# Altium schematic sheet [11] - USB 3.0 DRP I.SchDoc("[11] - USB 3.0 DRP I")
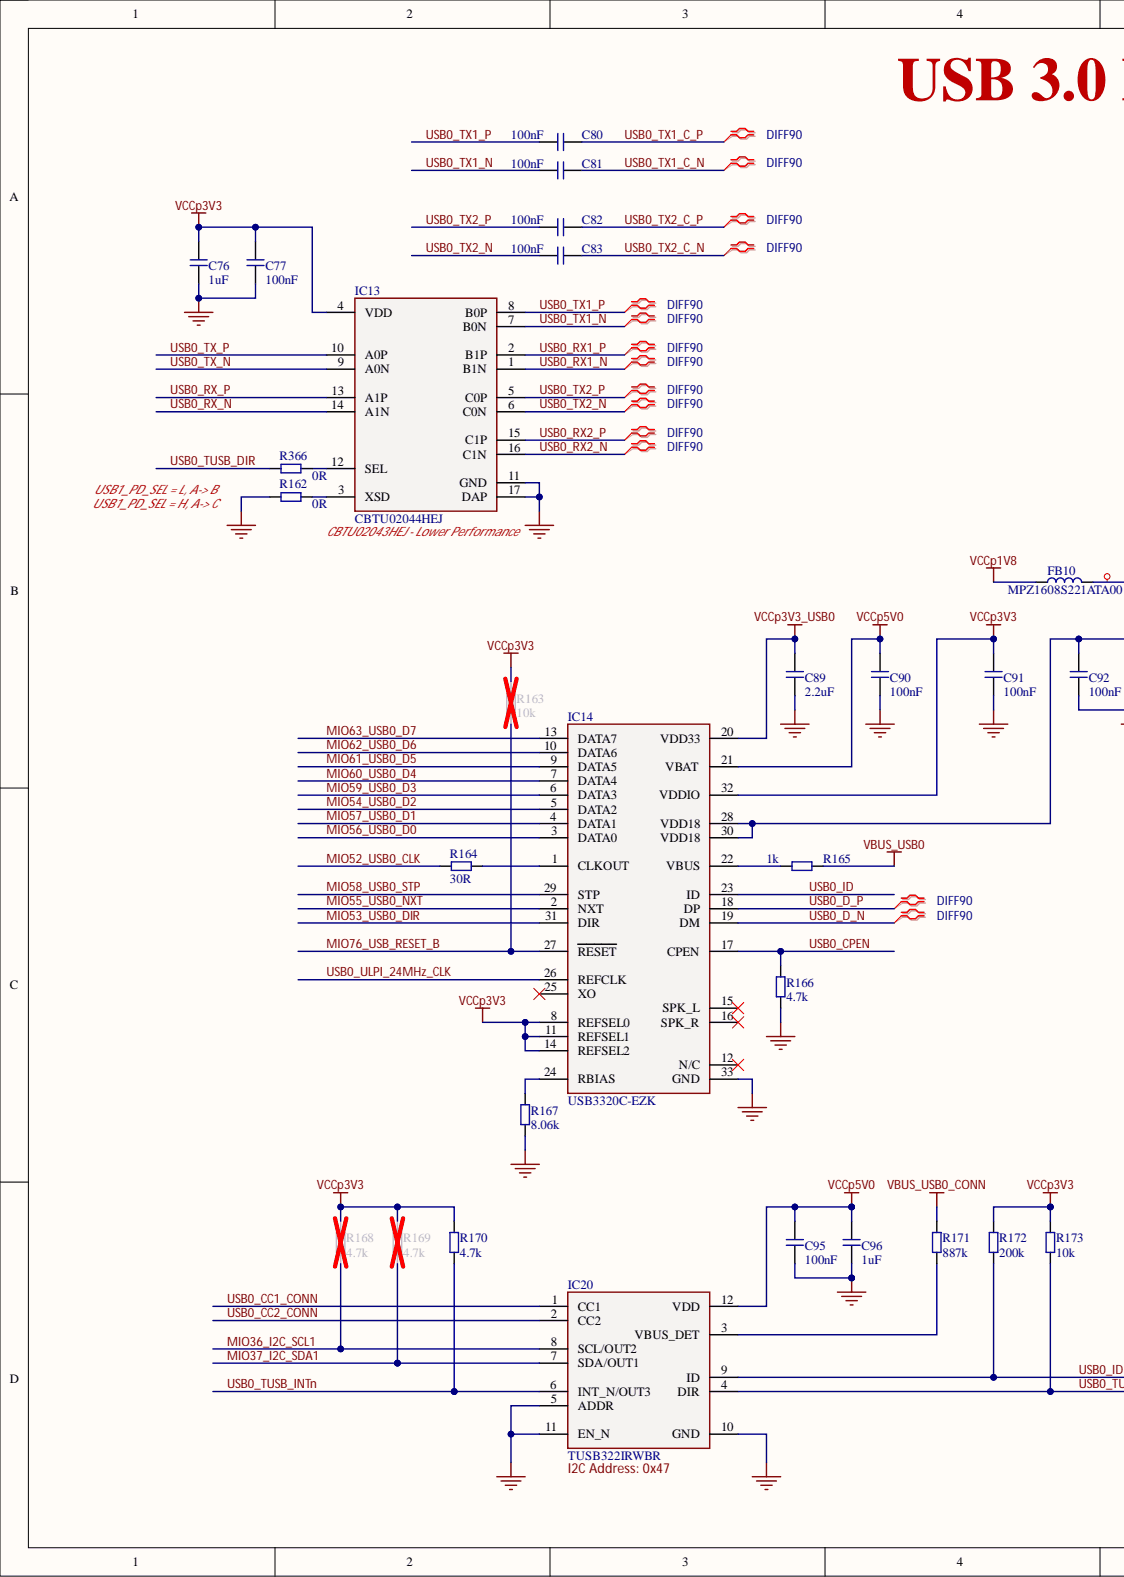
[diagram p0: [11] - USB 3.0 DRP I.SchDoc, left]
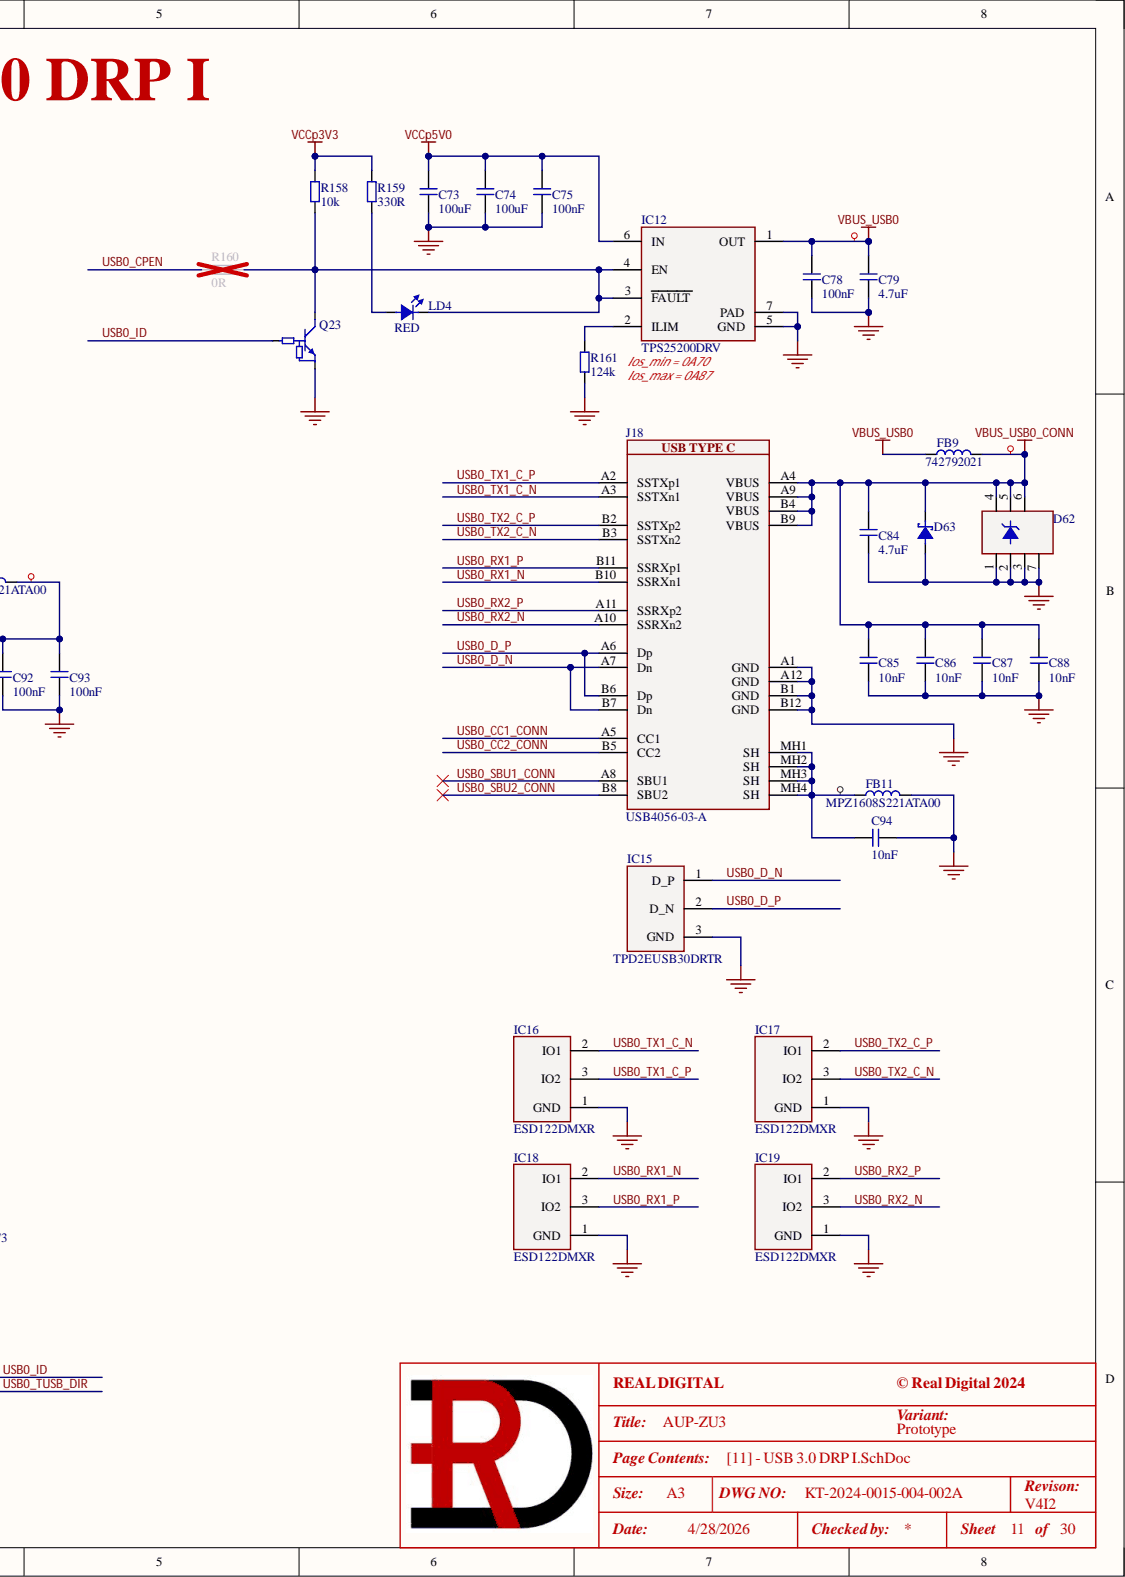
[diagram p1: [11] - USB 3.0 DRP I.SchDoc, right]

## Parts
C73  100uF  [C1210]
C74  100uF  [C1210]
C75  100nF  [C0402]
C76  1uF  [C0603]
C77  100nF  [C0201]
C78  100nF  [C0402]
C79  4.7uF  [C0603]
C80  100nF  [C0201]
C81  100nF  [C0201]
C82  100nF  [C0201]
C83  100nF  [C0201]
C84  4.7uF  [C0603]
C85  10nF  [C0402]
C86  10nF  [C0402]
C87  10nF  [C0402]
C88  10nF  [C0402]
C89  2.2uF  [C0603]
C90  100nF  [C0201]
C91  100nF  [C0201]
C92  100nF  [C0201]
C93  100nF  [C0201]
C94  10nF  [C0402]
C95  100nF  [C0201]
C96  1uF  [C0402]
D62  TVS0500DRVR  [DRV0006A_WSON_6 - TI]  (value not drawn)
D63  NSR20F30NXT5G  [DSN2 - ONSEMI]  (value not drawn)
FB9  742792021  [BLM21]
FB10  MPZ1608S221ATA00  [MPZ1608]
FB11  MPZ1608S221ATA00  [MPZ1608]
IC12  TPS25200DRV  [DRV0006A_WSON_6 - TI]
IC13  CBTU02044HEJ  [SOT1832-1_HUQFN16 - NXP]
IC14  USB3320C-EZK  [QFN32_5x5mm - Microchip]
IC15  TPD2EUSB30DRTR  [DRT0003A - TI]
IC16  ESD122DMXR  [DMX0003A - TI]
IC17  ESD122DMXR  [DMX0003A - TI]
IC18  ESD122DMXR  [DMX0003A - TI]
IC19  ESD122DMXR  [DMX0003A - TI]
IC20  TUSB322IRWBR  [RWB0012A - TI]
J18  USB4056-03-A  [USB4056-03-A - GCT]
LD4  RED  [LD0603_RED]
Q23  DTC043ZEBTL  [SC89-EMT3F]  (value not drawn)
R158  10k  [R0402]
R159  330R  [R0402]
R160  0R  [R0402]
R161  124k  [R0402]
R162  0R  [R0402]
R163  10k  [R0402]
R164  30R  [R0201]
R165  1k  [R0402]
R166  4.7k  [R0402]
R167  8.06k  [R0402]
R168  4.7k  [R0402]
R169  4.7k  [R0402]
R170  4.7k  [R0402]
R171  887k  [R0402]
R172  200k  [R0402]
R173  10k  [R0402]
R366  0R  [R0402]

## Nets
GND: C73.1 C74.1 C75.1 C76.1 C77.1 C78.1 C79.1 C84.1 C85.1 C86.1 C87.1 C88.1 C89.1 C90.1 C91.1 C92.1 C93.1 C94.2 C95.1 C96.1 D62.1 D62.2 D62.3 D62.7 D63.A FB11.2 IC12.5(GND) IC12.7(PAD) IC13.11(GND) IC13.17(DAP) IC14.33(GND) IC15.3 IC16.1 IC17.1 IC18.1 IC19.1 IC20.5(ADDR) IC20.10(GND) IC20.11(EN_N) J18.A1(GND) J18.A12(GND) J18.B1(GND) J18.B12(GND) Q23.GND R161.1 R162.2 R166.1 R167.1
VBUS_USB0: C78.2 C79.2 FB9.1 IC12.1(OUT) R165.2
VBUS_USB0_CONN: C84.2 C85.2 C86.2 C87.2 C88.2 D62.4 D62.5 D62.6 D63.K FB9.2 J18.A4(VBUS) J18.A9(VBUS) J18.B4(VBUS) J18.B9(VBUS) R171.2
VCCp1V8: FB10.2
VCCp3V3: C76.2 C77.2 C91.2 IC13.4(VDD) IC14.8(REFSEL0) IC14.11(REFSEL1) IC14.14(REFSEL2) IC14.32(VDDIO) R158.2 R159.2 R163.2 R168.2 R169.2 R170.2 R172.2 R173.2
VCCp3V3_USB0: C89.2 IC14.20(VDD33)
VCCp5V0: C73.2 C74.2 C75.2 C90.2 C95.2 C96.2 IC12.6(IN) IC14.21(VBAT) IC20.12(VDD)
MIO36_I2C_SCL1: IC20.8(SCL/OUT2) R168.1
MIO37_I2C_SDA1: IC20.7(SDA/OUT1) R169.1
MIO52_USB0_CLK: R164.2
MIO53_USB0_DIR: IC14.31(DIR)
MIO54_USB0_D2: IC14.5(DATA2)
MIO55_USB0_NXT: IC14.2(NXT)
MIO56_USB0_D0: IC14.3(DATA0)
MIO57_USB0_D1: IC14.4(DATA1)
MIO58_USB0_STP: IC14.29(STP)
MIO59_USB0_D3: IC14.6(DATA3)
MIO60_USB0_D4: IC14.7(DATA4)
MIO61_USB0_D5: IC14.9(DATA5)
MIO62_USB0_D6: IC14.10(DATA6)
MIO63_USB0_D7: IC14.13(DATA7)
MIO76_USB_RESET_B: IC14.27(RESET) R163.1
USB0_CC1_CONN: IC20.1(CC1) J18.A5(CC1)
USB0_CC2_CONN: IC20.2(CC2) J18.B5(CC2)
USB0_CPEN: IC14.17(CPEN) R160.1 R166.2
USB0_D_N: IC14.19(DM) IC15.1 J18.A7(Dn) J18.B7(Dn)
USB0_D_P: IC14.18(DP) IC15.2 J18.A6(Dp) J18.B6(Dp)
USB0_ID: IC14.23(ID) IC20.9(ID) Q23.IN R172.1
USB0_RX1_N: IC13.1(B1N) IC18.2 J18.B10(SSRXn1)
USB0_RX1_P: IC13.2(B1P) IC18.3 J18.B11(SSRXp1)
USB0_RX2_N: IC13.16(C1N) IC19.3 J18.A10(SSRXn2)
USB0_RX2_P: IC13.15(C1P) IC19.2 J18.A11(SSRXp2)
USB0_RX_N: IC13.14(A1N)
USB0_RX_P: IC13.13(A1P)
USB0_SBU1_CONN: J18.A8(SBU1)
USB0_SBU2_CONN: J18.B8(SBU2)
USB0_TUSB_DIR: IC20.4(DIR) R173.1 R366.2
USB0_TUSB_INTn: IC20.6(INT_N/OUT3) R170.1
USB0_TX1_C_N: C81.2 IC16.2 J18.A3(SSTXn1)
USB0_TX1_C_P: C80.2 IC16.3 J18.A2(SSTXp1)
USB0_TX1_N: C81.1 IC13.7(B0N)
USB0_TX1_P: C80.1 IC13.8(B0P)
USB0_TX2_C_N: C83.2 IC17.3 J18.B3(SSTXn2)
USB0_TX2_C_P: C82.2 IC17.2 J18.B2(SSTXp2)
USB0_TX2_N: C83.1 IC13.6(C0N)
USB0_TX2_P: C82.1 IC13.5(C0P)
USB0_TX_N: IC13.9(A0N)
USB0_TX_P: IC13.10(A0P)
USB0_ULPI_24MHz_CLK: IC14.26(REFCLK)
NetC92_2: C92.2 C93.2 FB10.1 IC14.28(VDD18) IC14.30(VDD18)
NetC94_1: C94.1 FB11.1 J18.MH1(SH) J18.MH2(SH) J18.MH3(SH) J18.MH4(SH)
NetIC12_2: IC12.2(ILIM) R161.2
NetIC12_3: IC12.3(FAULT) IC12.4(EN) LD4.K Q23.OUT R158.1 R160.2
NetIC13_3: IC13.3(XSD) R162.1
NetIC13_12: IC13.12(SEL) R366.1
NetIC14_1: IC14.1(CLKOUT) R164.1
NetIC14_22: IC14.22(VBUS) R165.1
NetIC14_24: IC14.24(RBIAS) R167.2
NetIC20_3: IC20.3(VBUS_DET) R171.1
NetLD4_A: LD4.A R159.1

Not connected: IC14 12(N/C) 15(SPK_L) 16(SPK_R) 25(XO)

## Library data of the parts
C73 C74 = GRM32ER61A107ME20K: CAP CER 100uF 10V X5R 1210; Manufacturer 1: Murata Electronics; .Checked_PCB: RV; C P/N: RD-111-0112; LoadStatus: Load; Tolerance: 20%; Category: Capacitors; Component Kind: Standard; Library Name: KT-RealDigital.IntLib; Manufacturer 1 Part Number: GRM32ER61A107ME20K; Temperature Coefficient: X5R; Voltage - Rated: 10V; .Checked_SCH: RV; .Creator: RV
C75 C78 = GRM155R71E104KE14J: CAP CER 0.1uF 25V X7R 0402; Manufacturer 1: Murata Electronics; .Checked_PCB: AC; .Creator: RV; C P/N: RD-111-0006; LoadStatus: Load; Tolerance: 10%; Category: Capacitors; Component Kind: Standard; Library Name: KT-RealDigital.IntLib; Manufacturer 1 Part Number: GRM155R71E104KE14J; Temperature Coefficient: X7R; Voltage - Rated: 25V; .Checked_SCH: AC
C76 = GRM188R71A105KA61D: CAP CER 1uF 10V X7R 0603; Manufacturer 1: Murata Electronics; .Checked_PCB: AC; .Creator: RV; C P/N: RD-111-0071; LoadStatus: Load; Tolerance: 10%; Category: Capacitors; Component Kind: Standard; Library Name: KT-RealDigital.IntLib; Manufacturer 1 Part Number: GRM188R71A105KA61D; Temperature Coefficient: X7R; Voltage - Rated: 10V; .Checked_SCH: AC
C77 C80-C83 C90-C93 C95 = GRM033C71C104KE14D: CAP CER 0.1uF 16V X7S 0201; Manufacturer 1: Murata Electronics; .Checked_PCB: RV; C P/N: 111-0800; LoadStatus: Load; Tolerance: 10%; Category: Capacitors; Component Kind: Standard; Library Name: KT-RealDigital.IntLib; Manufacturer 1 Part Number: GRM033C71C104KE14D; Temperature Coefficient: X7S; Voltage - Rated: 16V; .Checked_SCH: RV; .Creator: RV
C79 C84 = GRM188R6YA475KE15D: CAP CER 4.7uF 35V X5R 0603; Manufacturer 1: Murata Electronics; .Checked_PCB: DG; .Creator: RV; C P/N: RD-111-0064; LoadStatus: Load; Tolerance: 10%; Category: Capacitors; Component Kind: Standard; Library Name: KT-RealDigital.IntLib; Manufacturer 1 Part Number: GRM188R6YA475KE15D; Temperature Coefficient: X5R; Voltage - Rated: 35V; .Checked_SCH: DG
C85-C88 C94 = GRM155R71H103KA88J: CAP CER 10000pF 50V X7R 0402; Manufacturer 1: Murata Electronics; .Checked_PCB: AC; .Creator: RV; C P/N: RD-111-0003; LoadStatus: Load; Tolerance: 10%; Category: Capacitors; Component Kind: Standard; Library Name: KT-RealDigital.IntLib; Manufacturer 1 Part Number: GRM155R71H103KA88J; Temperature Coefficient: X7R; Voltage - Rated: 50V; .Checked_SCH: AC
C89 = GRM188R71A225KE15J: CAP CER 2.2uF 10V X7R 0603; Manufacturer 1: Murata Electronics; .Checked_PCB: AC; .Creator: RV; C P/N: RD-111-0023; LoadStatus: Load; Tolerance: 10%; Category: Capacitors; Component Kind: Standard; Library Name: KT-RealDigital.IntLib; Manufacturer 1 Part Number: GRM188R71A225KE15J; Temperature Coefficient: X7R; Voltage - Rated: 10V; .Checked_SCH: AC
C96 = GRM155Z71A105KE01J: CAP CER 1uF 10V X7R 0402; Manufacturer 1: Murata Electronics; .Checked_PCB: RV; C P/N: RD-111-0058; LoadStatus: Load; Tolerance: 10%; Category: Capacitors; Component Kind: Standard; Library Name: KT-RealDigital.IntLib; Manufacturer 1 Part Number: GRM155Z71A105KE01J; Temperature Coefficient: X7R; Voltage - Rated: 10V; .Checked_SCH: RV; .Creator: RV
D62 = TVS0500DRVR: 5V flat-clamp surge protection device; Manufacturer 1: Texas Instruments; .Checked_PCB: DG; .Creator: RV; C P/N: RD-121-0528; LoadStatus: Load; Category: TVS - Diodes; Component Kind: Standard; Library Name: KT-RealDigital.IntLib; Manufacturer 1 Part Number: TVS0500DRVR; .Checked_SCH: DG
D63 = NSR20F30NXT5G: DIODE SCHOTTKY 30V 2A 2DSN; Manufacturer 1: onsemi; .Checked_PCB: RV; C P/N: RD-121-0014; LoadStatus: Load; Category: Diodes; Component Kind: Standard; Library Name: KT-RealDigital.IntLib; Manufacturer 1 Part Number: NSR20F30NXT5G; .Checked_SCH: RV; .Creator: RV
FB9 = 742792021: FERRITE BEAD 22 OHM 0805 1LN; Manufacturer 1: Würth Elektronik; .Checked_PCB: DG; .Creator: RV; C P/N: RD-131-0023; LoadStatus: Load; Category: Ferrite Beads; Component Kind: Standard; Library Name: KT-RealDigital.IntLib; Manufacturer 1 Part Number: 742792021; .Checked_SCH: DG
FB10 FB11 = MPZ1608S221ATA00: FERRITE BEAD 220 OHM 0603 1LN; Manufacturer 1: TDK Corporation; .Checked_PCB: AC; .Checked_SCH: RV; C P/N: CY-121-0068; LoadStatus: Load; Category: Ferrite Beads; Component Kind: Standard; Library Name: KT-RealDigital.IntLib; Manufacturer 1 Part Number: MPZ1608S221ATA00; .Creator: RV
IC12 = TPS25200DRV: IC PWR MGR EFUSE 6.5V 2.8A 6SON; Manufacturer 1: Texas Instruments; .Checked_PCB: RV; C P/N: RD-126-0094; LoadStatus: Load; Category: IC; Component Kind: Standard; Library Name: KT-RealDigital.IntLib; Manufacturer 1 Part Number: TPS25200DRV; .Checked_SCH: RV; .Creator: RV
IC13 = CBTU02044HEJ: SWITCH 1-2 PCIE GEN4 HUQFN16; Manufacturer 1: NXP USA Inc.; .Checked_PCB: DG; .Creator: RV; C P/N: RD-126-0100; LoadStatus: Load; Category: IC; Component Kind: Standard; Library Name: KT-RealDigital.IntLib; Manufacturer 1 Part Number: CBTU02044HEJ; .Checked_SCH: DG
IC14 = USB3320C-EZK: IC TRANSCEIVER HALF 1/1 32QFN; Manufacturer 1: Microchip Technology; .Checked_PCB: RV; C P/N: 126-1133; LoadStatus: Load; Category: IC; Component Kind: Standard; Library Name: KT-RealDigital.IntLib; Manufacturer 1 Part Number: USB3320C-EZK; .Checked_SCH: RV; .Creator: RV
IC15 = TPD2EUSB30DRTR: TVS DIODE 5.5V 8V SOT3; Manufacturer 1: Texas Instruments; .Checked_PCB: RV; C P/N: 121-0524; LoadStatus: Load; Category: TVS - Diodes; Component Kind: Standard; Library Name: KT-RealDigital.IntLib; Manufacturer 1 Part Number: TPD2EUSB30DRTR; .Checked_SCH: RV; .Creator: RV
IC16-IC19 = ESD122DMXR: TVS DIODE 3.6VWM 8.4VC 3X2SON; Manufacturer 1: Texas Instruments; .Checked_PCB: DG; .Creator: RV; C P/N: 121-0550; LoadStatus: Load; Category: TVS - Diodes; Component Kind: Standard; Library Name: KT-RealDigital.IntLib; Manufacturer 1 Part Number: ESD122DMXR; .Checked_SCH: DG
IC20 = TUSB322IRWBR: IC INTERFACE SPECIALIZED 12X2QFN; Manufacturer 1: Texas Instruments; .Checked_PCB: RV; C P/N: 126-1503; LoadStatus: Load; Category: IC; Component Kind: Standard; Library Name: KT-RealDigital.IntLib; Manufacturer 1 Part Number: TUSB322IRWBR; .Checked_SCH: RV; .Creator: RV
J18 = USB4056-03-A: USB C 3.2 REC, HORZ, HYBRID PCB; Manufacturer 1: GCT; .Checked_PCB: RV; C P/N: EP-163-0073; LoadStatus: Load; Category: Connectors; Component Kind: Standard; Library Name: KT-RealDigital.IntLib; Manufacturer 1 Part Number: USB4056-03-A; .Checked_SCH: RV; .Creator: RV
LD4 = LED_RED: LED RED 0603; Manufacturer 1: EVERLIGHT ELECTRONICS CO.,LTD.; Manufacturer 1 Part Number: 19-21SURC/S530-XX/TR8; .Checked_PCB: AC; .Creator: RV; C P/N: 121-0001; LoadStatus: Load; Category: Led; Component Kind: Standard; Library Name: KT-RealDigital.IntLib; .Checked_SCH: AC
Q23 = DTC043ZEBTL: TRANS PREBIAS NPN 50V EMT3F; Manufacturer 1: Rohm Semiconductor; .Checked_PCB: RV; C P/N: RD-121-0006; LoadStatus: Load; Category: BJTs; Component Kind: Standard; Library Name: KT-RealDigital.IntLib; Manufacturer 1 Part Number: DTC043ZEBTL; .Checked_SCH: RV; .Creator: RV
R158 = RT0402BRB0710KL: RES SMD 10K OHM 0.1% 1/16W 0402; Manufacturer 1: YAGEO; .Checked_PCB: AC; .Creator: RV; C P/N: 101-0862; LoadStatus: Load; Tolerance: 0.1%/TK10; Category: Resistors; Component Kind: Standard; Library Name: KT-RealDigital.IntLib; Manufacturer 1 Part Number: RT0402BRB0710KL; .Checked_SCH: AC
R159 = RC0402JR-07330RL: RES SMD 330 OHM 5% 1/16W 0402; Manufacturer 1: Yageo; .Checked_PCB: AC; .Creator: RV; C P/N: 101-0112; LoadStatus: Load; Tolerance: 5%/TK100; Category: Resistors; Component Kind: Standard; Library Name: KT-RealDigital.IntLib; Manufacturer 1 Part Number: RC0402JR-07330RL; .Checked_SCH: AC
R160 R162 R366 = RC0402FR-070RL: RES SMD 0 OHM JUMPER 1/16W 0402; Manufacturer 1: YAGEO; .Checked_PCB: AC; .Creator: RV; C P/N: RD-101-0003; LoadStatus: Load; Category: Resistors; Component Kind: Standard; Library Name: KT-RealDigital.IntLib; Manufacturer 1 Part Number: RC0402FR-070RL; Tolerance: Jumper; .Checked_SCH: AC
R161 = RT0402BRD07124KL: RES SMD 124K OHM 0.1% 1/16W 0402; Manufacturer 1: Yageo; .Checked_PCB: RV; C P/N: RD-101-0062; LoadStatus: Load; Tolerance: 0.1%/TK25; Category: Resistors; Component Kind: Standard; Library Name: KT-RealDigital.IntLib; Manufacturer 1 Part Number: RT0402BRD07124KL; .Checked_SCH: RV; .Creator: RV
R163 = RC0402JR-0710KL: RES SMD 10K OHM 5% 1/16W 0402; Manufacturer 1: Yageo; .Checked_PCB: AC; .Creator: RV; C P/N: 101-0047; LoadStatus: Load; Tolerance: 5%/TK100; Category: Resistors; Component Kind: Standard; Library Name: KT-RealDigital.IntLib; Manufacturer 1 Part Number: RC0402JR-0710KL; .Checked_SCH: AC
R164 = RC0201FR-0730RL: RES SMD 30 OHM 1% 1/20W 0201; Manufacturer 1: YAGEO; .Checked_PCB: RV; C P/N: RD-101-0009; LoadStatus: Load; Tolerance: 1%/TK200; Category: Resistors; Component Kind: Standard; Library Name: KT-RealDigital.IntLib; Manufacturer 1 Part Number: RC0201FR-0730RL; .Checked_SCH: RV; .Creator: RV
R165 = RC0402FR-071KL: RES SMD 1K OHM 1% 1/16W 0402; Manufacturer 1: Yageo; .Checked_PCB: RV; C P/N: RD-101-0010; LoadStatus: Load; Tolerance: 1%/TK100; Category: Resistors; Component Kind: Standard; Library Name: KT-RealDigital.IntLib; Manufacturer 1 Part Number: RC0402FR-071KL; .Checked_SCH: RV; .Creator: RV
R166 R168-R170 = RC0402FR-074K7P: RES SMD 4.7K OHM 1% 1/16W 0402; Manufacturer 1: Yageo; .Checked_PCB: AC; .Creator: RV; C P/N: 101-0129; LoadStatus: Load; Tolerance: 1%/TK100; Category: Resistors; Component Kind: Standard; Library Name: KT-RealDigital.IntLib; Manufacturer 1 Part Number: RC0402FR-074K7P; .Checked_SCH: AC
R167 = RC0402FR-078K06L: RES SMD 8.06K OHM 1% 1/16W 0402; Manufacturer 1: Yageo; .Checked_PCB: RV; C P/N: 101-0506; LoadStatus: Load; Tolerance: 1%/TK100; Category: Resistors; Component Kind: Standard; Library Name: KT-RealDigital.IntLib; Manufacturer 1 Part Number: RC0402FR-078K06L; .Checked_SCH: RV; .Creator: RV
R171 = RC0402FR-07887KL: RES 887K OHM 1% 1/16W 0402; Manufacturer 1: YAGEO; .Checked_PCB: RV; C P/N: 101-0090; LoadStatus: Load; Tolerance: 1%/TK100; Category: Resistors; Component Kind: Standard; Library Name: KT-RealDigital.IntLib; Manufacturer 1 Part Number: RC0402FR-07887KL; .Checked_SCH: RV; .Creator: RV
R172 = RC0402FR-07200KL: RES SMD 200K OHM 1% 1/16W 0402; Manufacturer 1: Yageo; .Checked_PCB: RV; C P/N: CY-101-0332; LoadStatus: Load; Tolerance: 1%/TK100; Category: Resistors; Component Kind: Standard; Library Name: KT-RealDigital.IntLib; Manufacturer 1 Part Number: RC0402FR-07200KL; .Checked_SCH: RV; .Creator: RV
R173 = RC0402FR-0710KL: RES SMD 10K OHM 1% 1/16W 0402; Manufacturer 1: Yageo; .Checked_PCB: AC; .Creator: RV; C P/N: RD-101-0020; LoadStatus: Load; Tolerance: 1%/TK100; Category: Resistors; Component Kind: Standard; Library Name: KT-RealDigital.IntLib; Manufacturer 1 Part Number: RC0402FR-0710KL; .Checked_SCH: AC
